# Altium schematic sheet IO.SchDoc
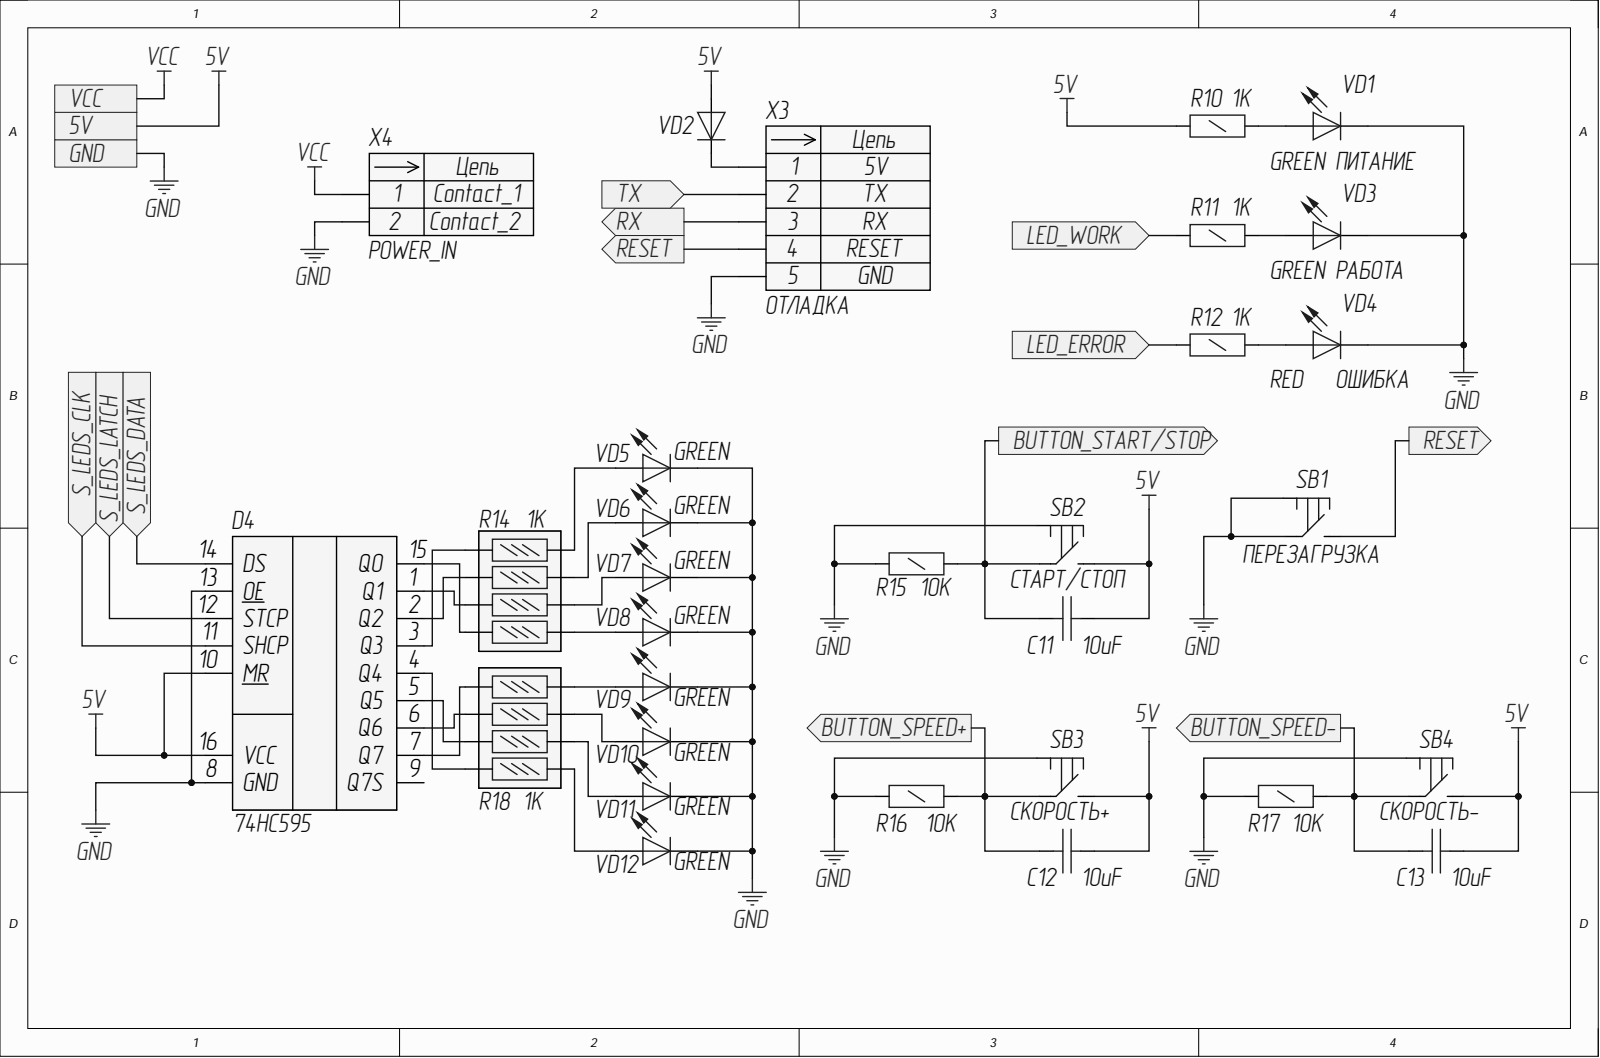
[diagram p0: IO.SchDoc]

## Parts
C11  10uF  [NPC0805]
C12  10uF  [NPC0805]
C13  10uF  [NPC0805]
D4  74HC595  [SOIC16]
R10  1K  [R0603]
R11  1K  [R0603]
R12  1K  [R0603]
R14  1K  [CAT16]
R15  10K  [R0603]
R16  10K  [R0603]
R17  10K  [R0603]
R18  1K  [CAT16]
SB1  Function: ПЕРЕЗАГРУЗКА  [1-1437565-6 WITH LABEL]
SB2  Function: СТАРТ/СТОП  [1-1437565-6 WITH LABEL]
SB3  Function: СКОРОСТЬ+  [1-1437565-6 WITH LABEL]
SB4  Function: СКОРОСТЬ-  [1-1437565-6 WITH LABEL]
VD1  GREEN  Name: ПИТАНИЕ  [0805_LED _GREEN]
VD2  (no value)  [SOD123]
VD3  GREEN  Name: РАБОТА  [0805_LED _GREEN]
VD4  RED  Name: ОШИБКА  [0805_LED _RED]
VD5  GREEN  [0805_LED _GREEN]
VD6  GREEN  [0805_LED _GREEN]
VD7  GREEN  [0805_LED _GREEN]
VD8  GREEN  [0805_LED _GREEN]
VD9  GREEN  [0805_LED _GREEN]
VD10  GREEN  [0805_LED _GREEN]
VD11  GREEN  [0805_LED _GREEN]
VD12  GREEN  [0805_LED _GREEN]
X3  ОТЛАДКА  Contact_1: 5V  Contact_2: TX  Contact_3: RX  Contact_4: RESET  Contact_5: GND  [5 PADSx2.54 WITH LABEL]
X4  POWER_IN  [PCB WITH TYPE C PD TRIGGER]

## Ports
5V, BUTTON_SPEED+, BUTTON_SPEED-, BUTTON_START/STOP, GND, LED_ERROR, LED_WORK, RESET, RX, S_LEDS_CLK, S_LEDS_DATA, S_LEDS_LATCH, TX, VCC

## Nets
5V: C11.2 C12.2 C13.2 D4.10(MR) D4.16(VCC) R10.1 SB2.2 SB3.2 SB4.2 VD2.2 ; port 5V
BUTTON_SPEED+: C12.1 R16.2 SB3.1 ; port BUTTON_SPEED+
BUTTON_SPEED-: C13.1 R17.2 SB4.1 ; port BUTTON_SPEED-
BUTTON_START/STOP: C11.1 R15.2 SB2.1 ; port BUTTON_START/STOP
GND: D4.8(GND) D4.13(OE) R15.1 R16.1 R17.1 SB1.1 SB1.3 SB2.3 SB3.3 SB4.3 VD1.1 VD3.1 VD4.1 VD5.1 VD6.1 VD7.1 VD8.1 VD9.1 VD10.1 VD11.1 VD12.1 X3.5 X4.2 ; port GND
LED_ERROR: R12.1 ; port LED_ERROR
LED_WORK: R11.1 ; port LED_WORK
RESET: SB1.2 X3.4 ; port RESET
RX: X3.3 ; port RX
S_LEDS_CLK: D4.11(SHCP) ; port S_LEDS_CLK
S_LEDS_DATA: D4.14(DS) ; port S_LEDS_DATA
S_LEDS_LATCH: D4.12(STCP) ; port S_LEDS_LATCH
TX: X3.2 ; port TX
VCC: X4.1 ; port VCC
NetD4_1: D4.1(Q1) R14.3
NetD4_2: D4.2(Q2) R14.2
NetD4_3: D4.3(Q3) R14.1
NetD4_4: D4.4(Q4) R18.4
NetD4_5: D4.5(Q5) R18.3
NetD4_6: D4.6(Q6) R18.2
NetD4_7: D4.7(Q7) R18.1
NetD4_15: D4.15(Q0) R14.4
NetR10_2: R10.2 VD1.2
NetR11_2: R11.2 VD3.2
NetR12_2: R12.2 VD4.2
NetR14_5: R14.5 VD8.2
NetR14_6: R14.6 VD7.2
NetR14_7: R14.7 VD6.2
NetR14_8: R14.8 VD5.2
NetR18_5: R18.5 VD12.2
NetR18_6: R18.6 VD11.2
NetR18_7: R18.7 VD10.2
NetR18_8: R18.8 VD9.2
NetVD2_1: VD2.1 X3.1

Not connected: D4 9(Q7S)

## Library data of the parts
C11-C13 = Capacitor ceramic: Конденсатор кер.; Component Kind: Standard; Library Name: LibSCH.SchLib; Value: 10uF
D4 = 74HC595: Сдвиговый регистр, 8 каналов; Component Kind: Standard; Library Name: LibSCH.SchLib
R10-R12 R15-R17 = Резистор  0,25Вт: Резистор 0,25Вт; Power rating: 0,25Вт; Component Kind: Standard; Library Name: LibSCH.SchLib
  R10-R12: Value: 1K
  R15-R17: Value: 10K
R14 R18 = Резисторная сборка 0,05Вт: Резистор 0,05Вт; Power rating: 0,05Вт; Component Kind: Standard; Library Name: LibSCH.SchLib; Value: 1K
SB1-SB4 = Button_SMD: Кнопка без фиксации; Component Kind: Standard; Library Name: LibSCH.SchLib
VD1 VD3 VD4 = LED: Светодиод SMD; Manufacturer: KGB; Forward voltage; 25мА: 2,15В; Max. forward current (continuous): 25мА; Component Kind: Standard; Library Name: LibSCH.SchLib; Package: 0603
  VD1 VD3: Color: GREEN
  VD4: Color: RED
VD2 = Diode: Диод; Component Kind: Standard; Library Name: LibSCH.SchLib
VD5-VD12 = LED: Светодиод SMD; Manufacturer: KGB; Forward voltage; 25мА: 2,15В; Max. forward current (continuous): 25мА; Name: ПИТАНИЕ; Color: GREEN; Component Kind: Standard; Library Name: LibSCH.SchLib; Package: 0603
X3 = Header_5_plg: Разъем - 5 конт. вилка; Component Kind: Standard; Library Name: LibSCH.SchLib; Name: ОТЛАДКА
X4 = Header_2_plg: Разъем - 2 конт. вилка; Component Kind: Standard; Contact_1: Contact_1; Contact_2: Contact_2; Library Name: LibSCH.SchLib; Name: POWER_IN
